# Altium schematic sheet Schematic.SchDoc
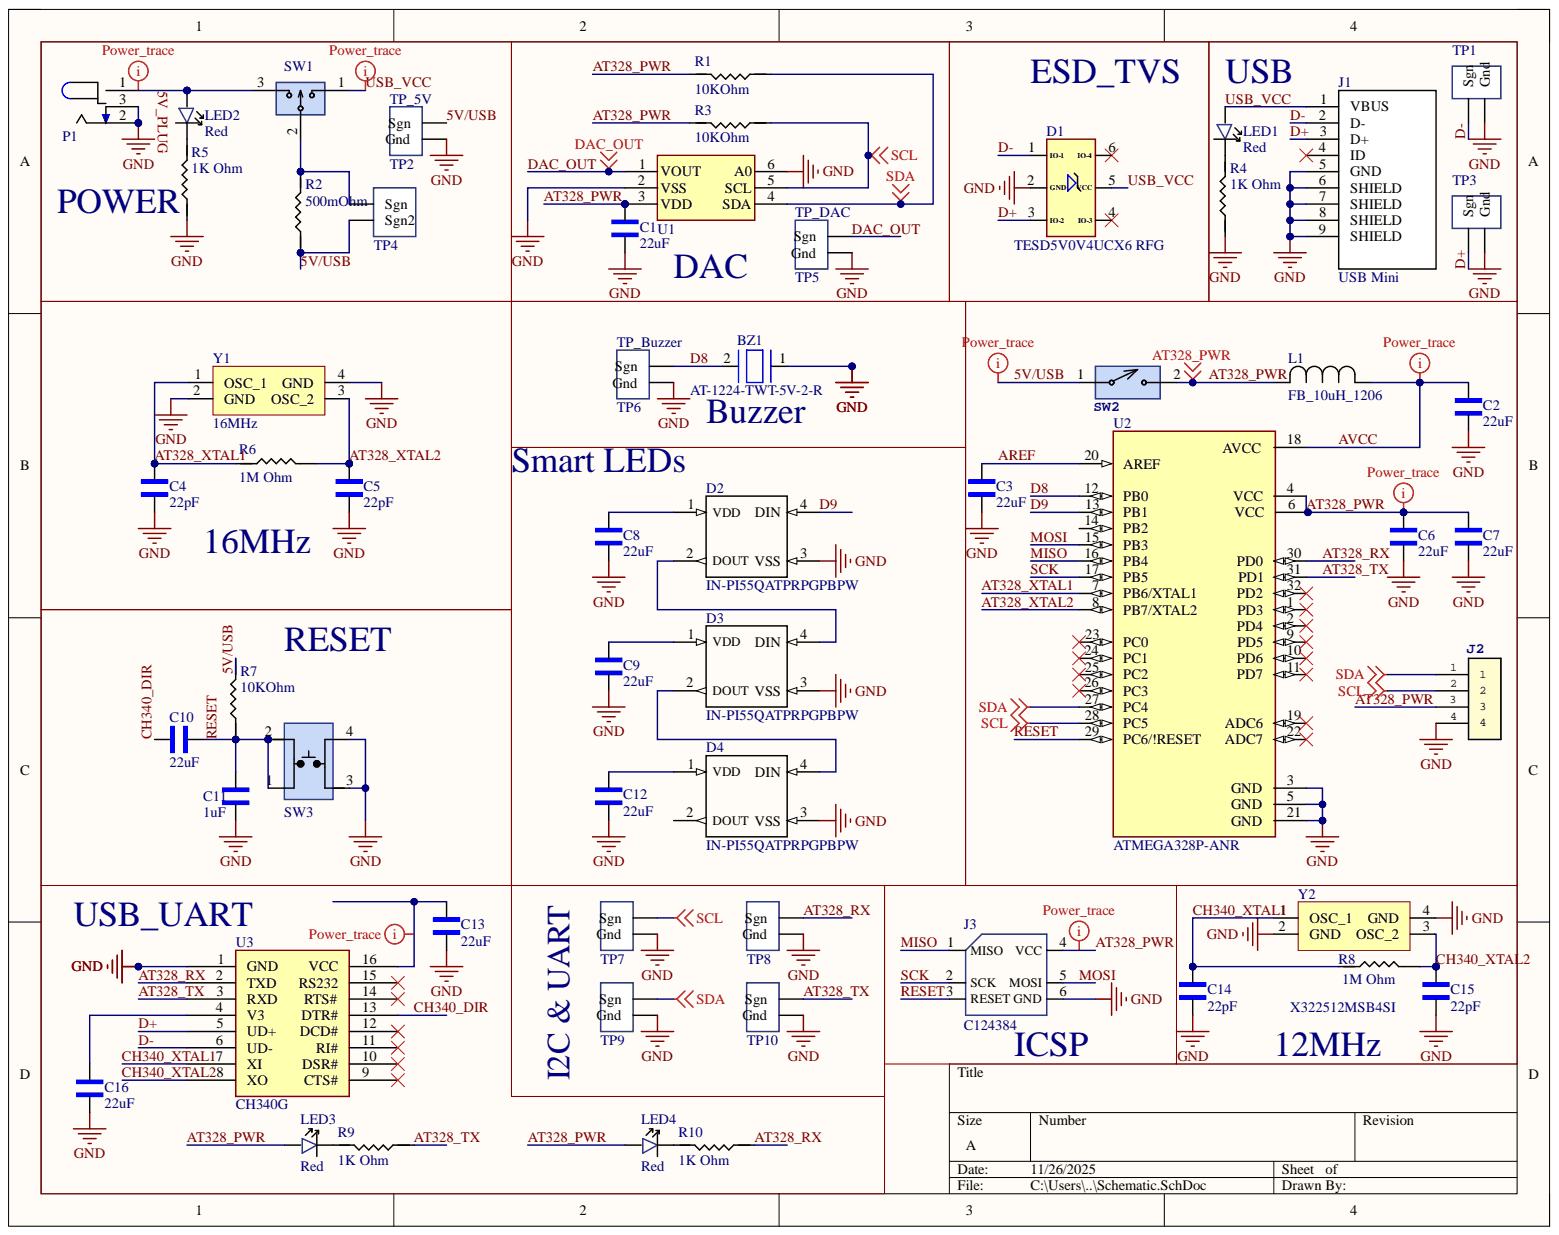
[diagram p0: Schematic.SchDoc]

## Parts
BZ1  AT-1224-TWT-5V-2-R  [BUZZER- TH]
C1  22uF  [1206_Passive_Capacitor]
C2  22uF  [1206_Passive_Capacitor]
C3  22uF  [1206_Passive_Capacitor]
C4  22pF  [1206_Passive_Capacitor]
C5  22pF  [1206_Passive_Capacitor]
C6  22uF  [1206_Passive_Capacitor]
C7  22uF  [1206_Passive_Capacitor]
C8  22uF  [1206_Passive_Capacitor]
C9  22uF  [1206_Passive_Capacitor]
C10  22uF  [1206_Passive_Capacitor]
C11  1uF  [1206_Passive_Capacitor]
C12  22uF  [1206_Passive_Capacitor]
C13  22uF  [1206_Passive_Capacitor]
C14  22pF  [1206_Passive_Capacitor]
C15  22pF  [1206_Passive_Capacitor]
C16  22uF  [1206_Passive_Capacitor]
D1  TESD5V0V4UCX6 RFG  [TVS]
D2  IN-PI55QATPRPGPBPW  [SERIAL_LED - duplicate]
D3  IN-PI55QATPRPGPBPW  [SERIAL_LED - duplicate]
D4  IN-PI55QATPRPGPBPW  [SERIAL_LED - duplicate]
J1  USB Mini  [USB_MINI_B]
J2  SAMTEC-SSQ-104-01-X-SJ  [JF-4_SSQ-104-01-X-S]  (value not drawn)
J3  LCSC Part#: C124384  [ICSP]  (hidden comment: NRPN032PAEN-RC)
L1  FB_10uH_1206  [AIML-1206_ABR-M]
LED1  Red  [LED_1206]
LED2  Red  [LED_1206]
LED3  Red  [LED_1206]
LED4  Red  [LED_1206]
P1  Power Jack  [Power_Jack]  (value not drawn)
R1  value 10KOhm  [1206_Passive_Resitor]  (hidden comment: 10k)
R2  500m  value 500mOhm  [1206_Passive_Resitor]
R3  value 10KOhm  [1206_Passive_Resitor]  (hidden comment: 10k)
R4  value 1K Ohm  [1206_Passive_Resitor]  (hidden comment: 1k)
R5  value 1K Ohm  [1206_Passive_Resitor]  (hidden comment: 1k)
R6  1M  value 1M Ohm  [1206_Passive_Resitor]
R7  value 10KOhm  [1206_Passive_Resitor]  (hidden comment: 10k)
R8  1M  value 1M Ohm  [1206_Passive_Resitor]
R9  value 1K Ohm  [1206_Passive_Resitor]  (hidden comment: 1k)
R10  value 1K Ohm  [1206_Passive_Resitor]  (hidden comment: 1k)
SW1  PREC003SAAN-RC  [3_PIN_100mil]  (value not drawn)
SW2  SW_2Pin_100mil_Switch  [2Pin-Header-Conn]  (value not drawn)
SW3  TL3305AF160QG  [Switch_JLC]  (value not drawn)
TP1  TP_D-  [TP10x_Probe]  (value not drawn)
TP2  TP_5V  [TP10x_Probe]
TP3  TP_D+  [TP10x_Probe]  (value not drawn)
TP4  TP_Inrush  [TP_Diff_Probe]  (value not drawn)
TP5  TP_DAC  [TP10x_Probe]
TP6  TP_Buzzer  [TP10x_Probe]
TP7  TP_SCL  [TP10x_Probe]  (value not drawn)
TP8  TP_AT328_RX  [TP10x_Probe]  (value not drawn)
TP9  TP_SDA  [TP10x_Probe]  (value not drawn)
TP10  TP_AT328_TX  [TP10x_Probe]  (value not drawn)
U1  DAC IC  [MCP4725]  (value not drawn)
U2  ATMEGA328P-ANR  [ATMega328P]
U3  CH340G  [USB to serial]
Y1  value 16MHz  [Crystal resonator]  (hidden comment: X322516MLB4SI)
Y2  X322512MSB4SI  [Crystal resonator]

## Nets
GND: BZ1.1 C1.1 C2.1 C3.1 C4.1 C5.1 C6.1 C7.1 C8.1 C9.1 C11.1 C12.1 C13.1 C14.1 C15.1 C16.1 D1.2(GND) D2.3(VSS) D3.3(VSS) D4.3(VSS) J1.5(GND) J1.6(SHIELD) J1.7(SHIELD) J1.8(SHIELD) J1.9(SHIELD) J2.4 J3.6(GND) P1.2 P1.3 R4.1 R5.1 SW3.3 SW3.4 TP1.2 TP2.2 TP3.2 TP5.2 TP6.2 TP7.2 TP8.2 TP9.2 TP10.2 U1.2(VSS) U1.6(A0) U2.3(GND) U2.5(GND) U2.21(GND) U3.1(GND) Y1.2(GND) Y1.4(GND) Y2.2(GND) Y2.4(GND)
SCL: J2.2 R3.1 TP7.1 U1.5(SCL) U2.28(PC5)
SDA: J2.1 R1.1 TP9.1 U1.4(SDA) U2.27(PC4)
5V/USB: R2.1 R7.2 SW2.1 TP2.1 TP4.2
5V_PLUG: LED2.1 P1.1 SW1.3
AREF: C3.2 U2.20(AREF)
AT328_PWR: C1.2 C6.2 C7.2 J2.3 J3.4(VCC) L1.2 LED3.1 LED4.1 R1.2 R3.2 SW2.2 U1.3(VDD) U2.4(VCC) U2.6(VCC)
AT328_RX: R10.1 TP8.1 U2.30(PD0) U3.2(TXD)
AT328_TX: R9.1 TP10.1 U2.31(PD1) U3.3(RXD)
AT328_XTAL1: C4.2 R6.1 U2.7(PB6/XTAL1) Y1.1(OSC_1)
AT328_XTAL2: C5.2 R6.2 U2.8(PB7/XTAL2) Y1.3(OSC_2)
AVCC: C2.2 L1.1 U2.18(AVCC)
CH340_DIR: C10.1 U3.13(DTR#)
CH340_XTAL1: C14.2 R8.1 U3.7(XI) Y2.1(OSC_1)
CH340_XTAL2: C15.2 R8.2 U3.8(XO) Y2.3(OSC_2)
D8: BZ1.2 TP6.1 U2.12(PB0)
D9: D2.4(DIN) U2.13(PB1)
D+: D1.3(IO-2) J1.3(D+) TP3.1 U3.5(UD+)
D-: D1.1(IO-1) J1.2(D-) TP1.1 U3.6(UD-)
DAC_OUT: TP5.1 U1.1(VOUT)
MISO: J3.1(MISO) U2.16(PB4)
MOSI: J3.5(MOSI) U2.15(PB3)
RESET: C10.2 C11.2 J3.3(RESET) R7.1 SW3.1 SW3.2 U2.29(PC6/!RESET)
SCK: J3.2(SCK) U2.17(PB5)
USB_VCC: D1.5(VCC) J1.1(VBUS) LED1.1 SW1.1
NetC8_2: C8.2 D2.1(VDD)
NetC9_2: C9.2 D3.1(VDD)
NetC12_2: C12.2 D4.1(VDD)
NetC13_2: C13.2 U3.16(VCC)
NetC16_2: C16.2 U3.4(V3)
NetD2_2: D2.2(DOUT) D3.4(DIN)
NetD3_2: D3.2(DOUT) D4.4(DIN)
NetLED1_2: LED1.2 R4.2
NetLED2_2: LED2.2 R5.2
NetLED3_2: LED3.2 R9.2
NetLED4_2: LED4.2 R10.2
NetR2_2: R2.2 SW1.2 TP4.1

Not connected: D1 4(IO-3) 6(IO-4); D4 2(DOUT); J1 4(ID); U2 1(PD3) 2(PD4) 9(PD5) 10(PD6) 11(PD7) 14(PB2) 19(ADC6) 22(ADC7) 23(PC0) 24(PC1) 25(PC2) 26(PC3) 32(PD2); U3 9(CTS#) 10(DSR#) 11(RI#) 12(DCD#) 14(RTS#) 15(RS232)

## Library data of the parts
BZ1 = BUZZER_1: Buzzers Transducer, Externally Driven Electromechanical/Magnetic 5 V 40mA 2.4kHz 87dB @ 5V, 10cm Through Hole PC Pins; Component Kind: Standard; Datasheet: https://www.puiaudio.com/media/SpecSheet/AT-1224-TWT-5V-2-R.pdf; Library Name: ECEN5730Lib_2023_05_DS.IntLib; MFR Part #: AT-1224-TWT-5V-2-R
C1-C3 C6-C10 C12 C13 C16 = C_22uF_1206: 22uF ±10% 25V X5R 1206 Multilayer Ceramic Capacitors MLCC - SMD/SMT RoHS; MFR Part #: CL31A226KAHNNNE; Type: basic; Component Kind: Standard; Datasheet: https://datasheet.lcsc.com/lcsc/1811031514_Samsung-Electro-Mechanics-CL31A226KAHNNNE_C12891.pdf; LCSC Part#: C12891; Library Name: ECEN5730Lib_2023_05_DS.IntLib; Value: 22uF
C4 C5 C14 C15 = C_22pF_1206: 22pF ±5% 1kV C0G 1206 Multilayer Ceramic Capacitors MLCC - SMD/SMT RoHS; MFR Part #: CC1206JKNPOCBN220; Type: Extended; Component Kind: Standard; Datasheet: https://datasheet.lcsc.com/lcsc/1810241219_YAGEO-CC1206JKNPOCBN220_C107174.pdf; LCSC Part#: C107174; Library Name: ECEN5730Lib_2023_05_DS.IntLib; Value: 22pF
C11 = C_1uF_1206: MULTILAYER CERAMIC CAPACITORS MLCC - SMD/SMT 1UF 50V 1206 ROHS; MFR Part #: CL31B105KBHNNNE; Type: Basic; Component Kind: Standard; Digikey Link: https://www.digikey.com/product-detail/en/C1206C105M4RACTU/399-8150-1-ND/3471873/?itemSeq=304982366; LCSC Part#: C1848; Library Name: ECEN5730Lib_2023_05_DS.IntLib; Value: 1uF
D1 = D_TVS_Diode_TESD5V0V4UCX6RFG: TVS SOT-23-6 ROHS; Manufacturer: Taiwan Semiconductor; Manufacturer Lifecycle Status: ACTIVE; Clamping Voltage: 15V; Min Breakdown Voltage: 6V; Number of Channels: 4; Number of Elements: 1; Peak Pulse Power: 75W; Peak Reverse Current: 100nA; Polarity: Unidirectional; Reverse Standoff Voltage: 5V; Case/Package: SOT-26; Category: TVS Diodes; China RoHS: Compliant; Component Kind: Standard; Library Name: ECEN5730Lib_2023_05_DS.IntLib; Lifecycle Status: Production; Manufacturer Part Number: TESD5V0V4UCX6 RFG; Max Operating Temperature: 150°C; Min Operating Temperature: -55°C; Number of Terminals: 6; Pricing: 1=0.16, 10=0.129, 100=0.107, 500=0.099, 1000=0.091 (USD); REACH SVHC: Yes; RoHS: Compliant; Schedule B: 8536300000, 8541100080, 8541100080|8541100080|8541100080|8541100080|8541100080; Stock: 19421; Supplier: DigiKey; Supplier Part Number: TESD5V0V4UCX6RFGCT-ND
D2-D4 = LED_Smart: LIGHT EMITTING DIODES (LED) RGB 620~630NM RED, 520~530NM GREEN, 465~475NM BLUE 600MCD@16MARED,1200MCD@16MAGREEN,300MCD@16MABLUE TOP VIEW SMD-4 ROHS; Manufacturer: XINGLIGHT; MFR Part #: XL-5050RGBC-WS2812B; Component Kind: Standard; DataSheet: https://datasheet.lcsc.com/lcsc/2301111130_XINGLIGHT-XL-5050RGBC-WS2812B_C2843785.pdf; LCSC Part#: C2843785; Library Name: ECEN5730Lib_2023_05_DS.IntLib
J1 = J_USB_B_Mini: Through Hole USB Connectors RoHS; MFR Part #: 920-462A2021D10102; Value: USB mini B; Component Kind: Standard; Datasheet: https://datasheet.lcsc.com/szlcsc/2103081233_Jing-Extension-of-the-Electronic-Co-920-462A2021D10102_C46398.pdf; LCSC Part#: C46398; Library Name: ECEN5730Lib_2023_05_DS.IntLib; Type: Extended
J2 = JF_4_PIN_FEMALE: 2.54mm 3A bronze -40℃~+105℃ Straight 1x4P Top 4 1 Square Holes Straight,P=2.54mm  Female Headers ROHS; Component Kind: Standard; LCSC PART#: C2718488; Library Name: ECEN5730Lib_2023_05_DS.IntLib; MFR PART #: SSQ-104-01-X-SJ
J3 = J_ICSP: Connector Header Through Hole 6 position 0.079" (2.00mm); Component Kind: Standard; Digikey Link: https://www.digikey.com/product-detail/en/NRPN032PAEN-RC/S5801-03-ND/804955/?itemSeq=304982355; Library Name: ECEN5730Lib_2023_05_DS.IntLib; MFR Part #: NRPN032PAEN-RC; Type: Extended
L1 = FB_10uH_1206: 25mA 10uH ±10% 800mΩ 1206  Inductors (SMD) ROHS; MFR PART #: CMI321609X100KT; Mfr_Name: FH (Guangdong Fenghua Advanced Tech); Type: Basic; Component Kind: Standard; DataSheet: https://datasheet.lcsc.com/lcsc/1810311314_FH--Guangdong-Fenghua-Advanced-Tech-CMI321609X100KT_C1051.pdf; LCSC PART#: C1051; Library Name: ECEN5730Lib_2023_05_DS.IntLib
LED1-LED4 = LED_RED_1206: Red 621~631nm 1206 Light Emitting Diodes (LED) RoHS; MFR Part #: 15-21/R6C-FQ1R1B/2T; Type: Extended; Component Kind: Standard; Datasheet: https://datasheet.lcsc.com/szlcsc/1811151241_Everlight-Elec-15-21-R6C-FQ1R1B-2T_C93133.pdf; LCSC Part#: C93133; Library Name: ECEN5730Lib_2023_05_DS.IntLib; Value: Red
P1 = P_Power Jack: Power Barrel Connector Jack 2.10mm ID (0.083"), 5.50mm OD (0.217") Through Hole, Right Angle; MFR Part #: PJ-102A; Value: CONN PWR JACK 2X5.5MM SOLDER; Component Kind: Standard; Digikey Link: https://www.digikey.com/product-detail/en/PJ-102A/CP-102A-ND/275425/?itemSeq=304982367; LCSC Part#: C136744; Library Name: ECEN5730Lib_2023_05_DS.IntLib; Type: Extended
R1 R3 R7 = R_10KOhm_1206: 10 kOhms ±5% 0.25W, 1/4W Chip Resistor 1206 (3216 Metric) Automotive AEC-Q200 Thick Film; MFR Part #: RMCF1206FT10K0; Component Kind: Standard; Digikey Link: https://www.digikey.com/en/products/detail/stackpole-electronics-inc/RMCF1206FT10K0/1759669; LCSC Part#: C17902; Library Name: ECEN5730Lib_2023_05_DS.IntLib; Type: Basic
R2 = R_500mOhm_1206: Resistors/Shunt 250mW 500mΩ ±800ppm/℃ ±5% 1206  Current Sense  Resistors ROHS; MFR Part #: 1206W4J050KT5E; Component Kind: Standard; Datasheet: https://datasheet.lcsc.com/lcsc/1810301438_UNI-ROYAL-Uniroyal-Elec-1206W4J050KT5E_C247433.pdf; LCSC Part#: C247433; Library Name: ECEN5730Lib_2023_05_DS.IntLib; Type: Extended
R4 R5 R9 R10 = R_1K_1206: CHIP RESISTOR - SURFACE MOUNT 1KOHMS ±1% 1/4W 1206 ROHS; MFR Part #: RNCP1206FTD1K00CT; Component Kind: Standard; Digikey Link: https://www.digikey.com/product-detail/en/RNCP1206FTD1K00/RNCP1206FTD1K00CT-ND/2240675/?itemSeq=304982373; LCSC Part#: C4410; Library Name: ECEN5730Lib_2023_05_DS.IntLib; Type: Basic
R6 R8 = R_1M_1206: CHIP RESISTOR - SURFACE MOUNT 1MOHMS ±1% 1/4W 1206 ROHS; MFR Part #: RMCF1206JT1M00; Component Kind: Standard; Digikey Link: https://www.digikey.com/product-detail/en/RMCF1206JT1M00/RMCF1206JT1M00CT-ND/1942830/?itemSeq=304982358; LCSC Part#: C17927; Library Name: ECEN5730Lib_2023_05_DS.IntLib; Type: Basic
SW1 = SW_3Pin_100mil_Switch: Connector Header Through Hole 3 position 0.100" (2.54mm); Value: CONN HEADER VERT 3POS 2.54MM; Component Kind: Standard; Digikey Link: https://www.digikey.com/product-detail/en/PREC003SAAN-RC/S1012EC-03-ND/2774851/?itemSeq=304982371; LCSC Part#: C49257; Library Name: ECEN5730Lib_2023_05_DS.IntLib; MFR Part #: PREC003SAAN-RC; Type: Extended
SW2 = SW_2Pin_100mil_Switch: 2Pin Header; Component Kind: Standard; LCSC Part#: C124375; Library Name: ECEN5730Lib_2023_05_DS.IntLib; Type: Extended
SW3 = SW_Push_Button_JLC: Tactile Switch SPST-NO Top Actuated Surface Mount; MFR Part #: KH-4.5X4.5X4.3H-STM; Component Kind: Standard; DataSheet: https://datasheet.lcsc.com/lcsc/2106081532_Shenzhen-Kinghelm-Elec-KH-4.5X4.5X4.3H-STM_C2837550.pdf; LCSC Part#: C2837550; Library Name: ECEN5730Lib_2023_05_DS.IntLib; Type: Extended
TP1-TP3 TP5-TP10 = TP_10x_Probe: Test Point 300 mil centers; Component Kind: Standard; Library Name: ECEN5730Lib_2023_05_DS.IntLib
TP4 = TP_Diff_Probe: Test Point 300 mil centers; Component Kind: Standard; Library Name: ECEN5730Lib_2023_05_DS.IntLib
U1 = U_MCP4725A0T-E/CH: IC DAC 12BIT V-OUT SOT23-6; Component Kind: Standard; Datasheet: https://ww1.microchip.com/downloads/en/DeviceDoc/22039d.pdf; LCSC Part#: C144198; Library Name: ECEN5730Lib_2023_05_DS.IntLib; MFR Part #: MCP4725A0T-E/CH; type: Extended
U2 = U_ATMega328P: AVR AVR® ATmega Microcontroller IC 8-Bit 20MHz 32KB (16K x 16) FLASH 32-TQFP (7x7); MFR Part #: ATMEGA328P-AU; Value: IC MCU 8BIT 32KB FLASH 32TQFP; Component Kind: Standard; Digikey Link: https://www.digikey.com/product-detail/en/ATMEGA328P-ANR/ATMEGA328P-ANRCT-ND/2774230/?itemSeq=304982365; LCSC Part#: C14877; Library Name: ECEN5730Lib_2023_05_DS.IntLib; Type: Basic
U3 = U_USBtoSerial: Transceiver USB 2.0 2Mbps SOP-16_150mil USB ICs RoHS; Component Kind: Standard; Datasheet: https://datasheet.lcsc.com/lcsc/2008191806_WCH-Jiangsu-Qin-Heng-CH340G_C14267.pdf; LCSC Part#: C14267; Library Name: ECEN5730Lib_2023_05_DS.IntLib; MFR Part #: CH340G; Type: Basic; Value: USB to Serial
Y1 = X_16Mhz_Res: ±10ppm 16000000Hz -40℃~85℃ 贴片无源晶振 60Ω 9pF SMD3225-4P Crystals ROHS; Component Kind: Standard; LCSC Part#: C13738; Library Name: ECEN5730Lib_2023_05_DS.IntLib; MFR Part #: X322516MLB4SI; Type: Basic
Y2 = X_12MHz_Res: SMD Crystal Resonators 12MHz ±10ppm SMD-3225_4P RoHS; Component Kind: Standard; Datasheet: https://datasheet.lcsc.com/szlcsc/Yangxing-Tech-X322512MSB4SI_C9002.pdf; LCSC Part#: C9002; Library Name: ECEN5730Lib_2023_05_DS.IntLib; MFR Part #: X322512MSB4SI; Type: Basic; Value: 12MHz
